# Altium schematic sheet Schematics.SchDoc
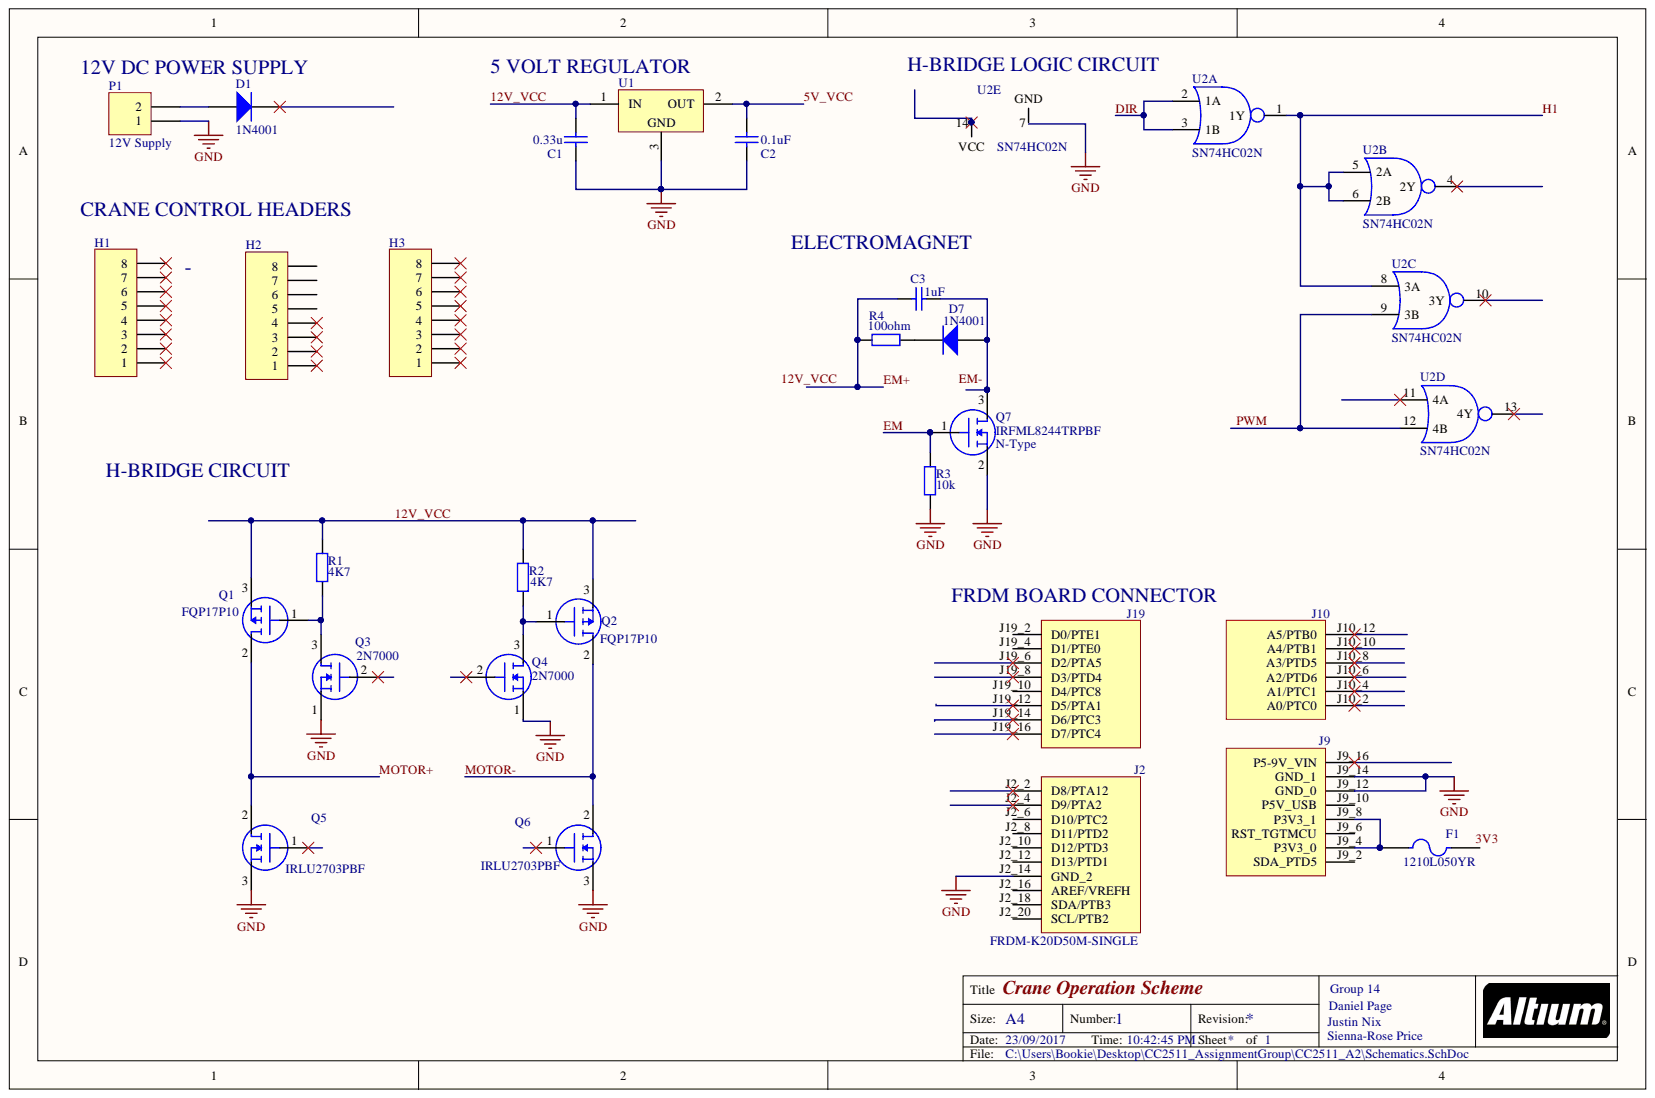
[diagram p0: Schematics.SchDoc]

## Parts
C1  value 0.33u  [RAD-0.3]  (hidden comment: Cap)
C2  value 0.1uF  [RAD-0.3]  (hidden comment: Cap)
C3  value 1uF  [RAD-0.3]  (hidden comment: Cap)
D1  1N4001  [DO-41]
D7  1N4001  [DO-41]
F1  1210L050YR  [Fuse-1210]
FRDM?  FRDM-K20D50M-SINGLE  [FRDM-K20D50M-SINGLE]
H1  Header 8  [HDR1X8]  (value not drawn)
H2  Header 8  [HDR1X8]  (value not drawn)
H3  Header 8  [HDR1X8]  (value not drawn)
P1  12V Supply  [HDR1X2]
Q1  FQP17P10  [TO-220]
Q2  FQP17P10  [TO-220]
Q3  2N7000  [TO-92]
Q4  2N7000  [TO-92]
Q5  IRLU2703PBF  [TO-251AA]
Q6  IRLU2703PBF  [TO-251AA]
Q7  IRFML8244TRPBF  [SOT23]
R1  value 4K7  [AXIAL-0.4]  (hidden comment: Res2)
R2  value 4K7  [AXIAL-0.4]  (hidden comment: Res2)
R3  value 10k  [AXIAL-0.4]  (hidden comment: Res2)
R4  100ohm  [AXIAL-0.4]
U1  (no value)  [TO-220]
U2  SN74HC02N  [DIP14]  (parts A,B,C,D,E)
  JCU-LOGO  [JCU-LOGO-1004]  (value not drawn)

## Nets
GND: C1.1 C2.1 FRDM?.J2_14(GND_2) FRDM?.J9_12(GND_0) FRDM?.J9_14(GND_1) P1.1 Q3.1 Q4.1 Q5.3 Q6.3 Q7.2 R3.1 U1.3
5V_VCC: C2.2 U1.2
12V_VCC: C1.2 Q1.3 Q2.3 R1.2 R2.2 U1.1
DIR: U2.2(1A) U2.3(1B)
EM: Q7.1 R3.2
EM+: C3.1 R4.2
H1: U2.1(1Y) U2.5(2A) U2.6(2B) U2.8(3A)
MOTOR+: Q1.2 Q5.2
MOTOR-: Q2.2 Q6.2
PWM: U2.9(3B) U2.12(4B)
NetC3_2: C3.2 D7.2 Q7.3
NetD1_2: D1.2 P1.2
NetD7_1: D7.1 R4.1
NetF1_1: F1.1 FRDM?.J9_4(P3V3_0) FRDM?.J9_8(P3V3_1)
NetQ1_1: Q1.1 Q3.3 R1.1
NetQ2_1: Q2.1 Q4.3 R2.1
3V3:
EM-:
N00012:

Not connected: D1 1; F1 2; FRDM? J2_2(D8/PTA12) J2_4(D9/PTA2) J2_6(D10/PTC2) J2_8(D11/PTD2) J2_10(D12/PTD3) J2_12(D13/PTD1) J2_16(AREF/VREFH) J2_18(SDA/PTB3) J2_20(SCL/PTB2) J9_2(SDA_PTD5) J9_6(RST_TGTMCU) J9_10(P5V_USB) J9_16(P5-9V_VIN) J10_2(A0/PTC0) J10_4(A1/PTC1) J10_6(A2/PTD6) J10_8(A3/PTD5) J10_10(A4/PTB1) J10_12(A5/PTB0) J19_2(D0/PTE1) J19_4(D1/PTE0) J19_6(D2/PTA5) J19_8(D3/PTD4) J19_10(D4/PTC8) J19_12(D5/PTA1) J19_14(D6/PTC3) J19_16(D7/PTC4); H1 1 2 3 4 5 6 7 8; H2 1 2 3 4 5 6 7 8; H3 1 2 3 4 5 6 7 8; Q3 2; Q4 2; Q5 1; Q6 1; U2 4(2Y) 7 10(3Y) 11(4A) 13(4Y) 14

## Library data of the parts
C1-C3 = Cap: Capacitor; Component Kind: Standard; LatestRevisionDate: 17-Jul-2002; LatestRevisionNote: Re-released for DXP Platform.; Library Name: Miscellaneous Devices.IntLib; PackageDescription: Radial Cap, Thru-Hole; 2 Leads; 0.3 in Pin Spacing; PackageReference: RAD-0.3; Published: 8-Jun-2000; Signal Integrity: Cap; Simulation: CAP; Publisher: Altium Limited
D1 D7 = 1N4001: Diode 1A 50V; Component Kind: Standard; Library Name: JCULibrary.IntLib
F1 = 1210L050YR: Polyfuse 0.5 A 1210 Package; Component Kind: Standard; Library Name: JCULibrary.IntLib
FRDM? = FRDM-K20D50M-SINGLE: FRDM-K20D50M SINGLE; Component Kind: Standard; Library Name: JCULibrary.IntLib
H1-H3 = Header 8: Header, 8-Pin; Component Kind: Standard; LatestRevisionDate: 17-Jul-2002; LatestRevisionNote: Re-released for DXP Platform.; Library Name: Miscellaneous Connectors.IntLib; Signal Integrity: Connector; Publisher: Altium Limited
P1 = Header 2: Header, 2-Pin; Component Kind: Standard; LatestRevisionDate: 17-Jul-2002; LatestRevisionNote: Re-released for DXP Platform.; Library Name: Miscellaneous Connectors.IntLib; Signal Integrity: Connector; Publisher: Altium Limited
Q1 Q2 = FQP17P10: P-Channel Power MOSFET 100 V 16.5 A; Component Kind: Standard; Library Name: JCULibrary.IntLib
Q3 Q4 = 2N7000: N-Channel Low Current MOSFET 200mA; Component Kind: Standard; Library Name: JCULibrary.IntLib
Q5 Q6 = IRLU2703PBF: N-Channel Power MOSFET 30 V 22 A; Component Kind: Standard; Library Name: JCULibrary.IntLib
Q7 = IRFML8244TRPBF: N-Channel Power MOSFET 25 V 5.8 A; Component Kind: Standard; Library Name: JCULibrary.IntLib
R1-R4 = Res2: Resistor; Component Kind: Standard; LatestRevisionDate: 17-Jul-2002; LatestRevisionNote: Re-released for DXP Platform.; Library Name: Miscellaneous Devices.IntLib; PackageDescription: Axial Device, Thru-Hole; 2 Leads; 0.4 in Pin Spacing; PackageReference: AXIAL-0.4; Published: 8-Jun-2000; Signal Integrity: Res; Simulation: RESISTOR; Publisher: Altium Limited
U1 = L7805ABP: Precision 5V 1A Regulator; Component Kind: Standard; Library Name: JCULibrary.IntLib
U2 = SN74HC02N: Quad 2-Input NOR Gate; Component Kind: Standard; Library Name: JCULibrary.IntLib
 = JCU-LOGO: James Cook University Logo; Component Kind: Standard; Library Name: JCULibrary.IntLib
